# Altium schematic sheet Top.DMX8x8A-v1.SchDoc
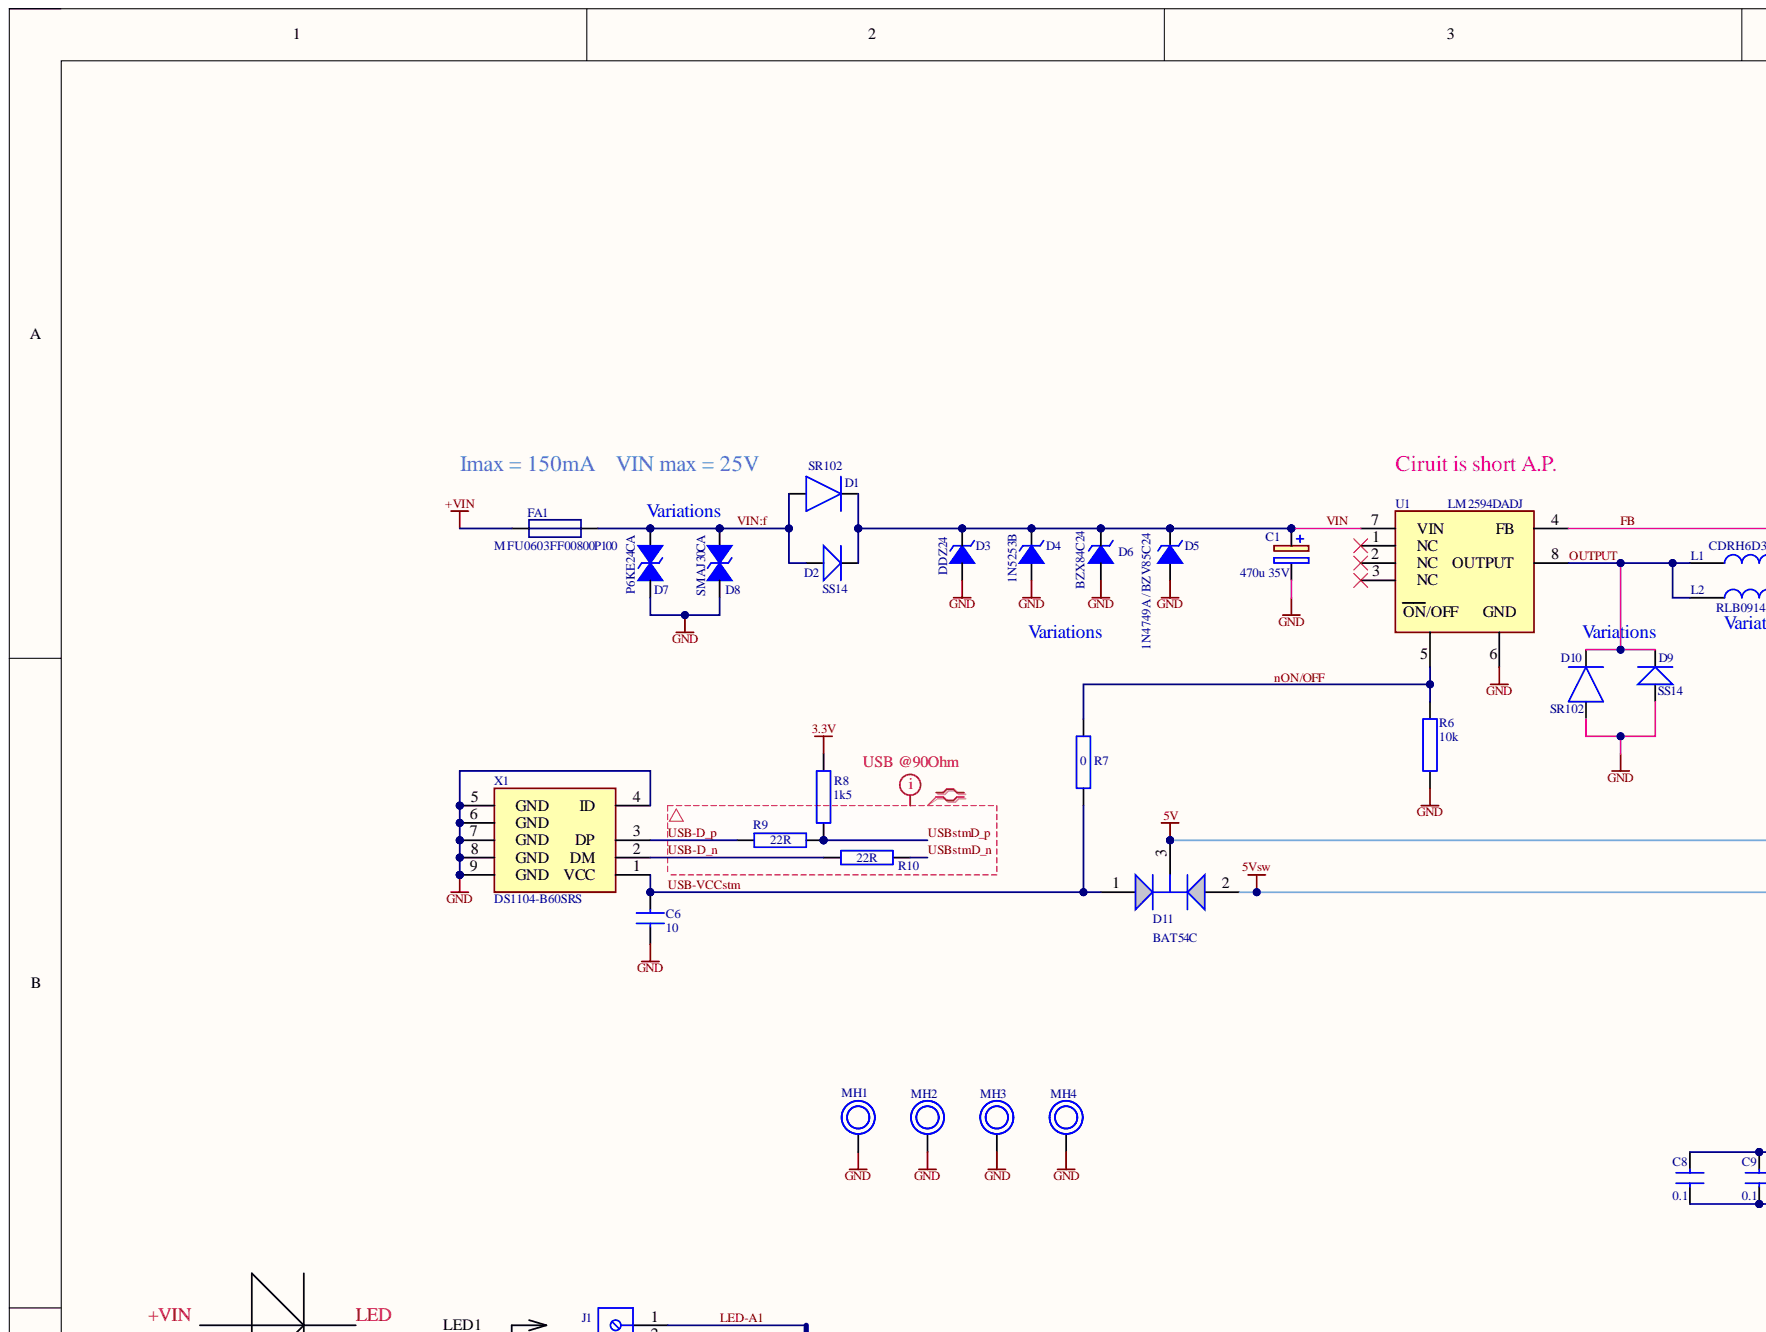
[diagram p0: Top.DMX8x8A-v1.SchDoc, top left]
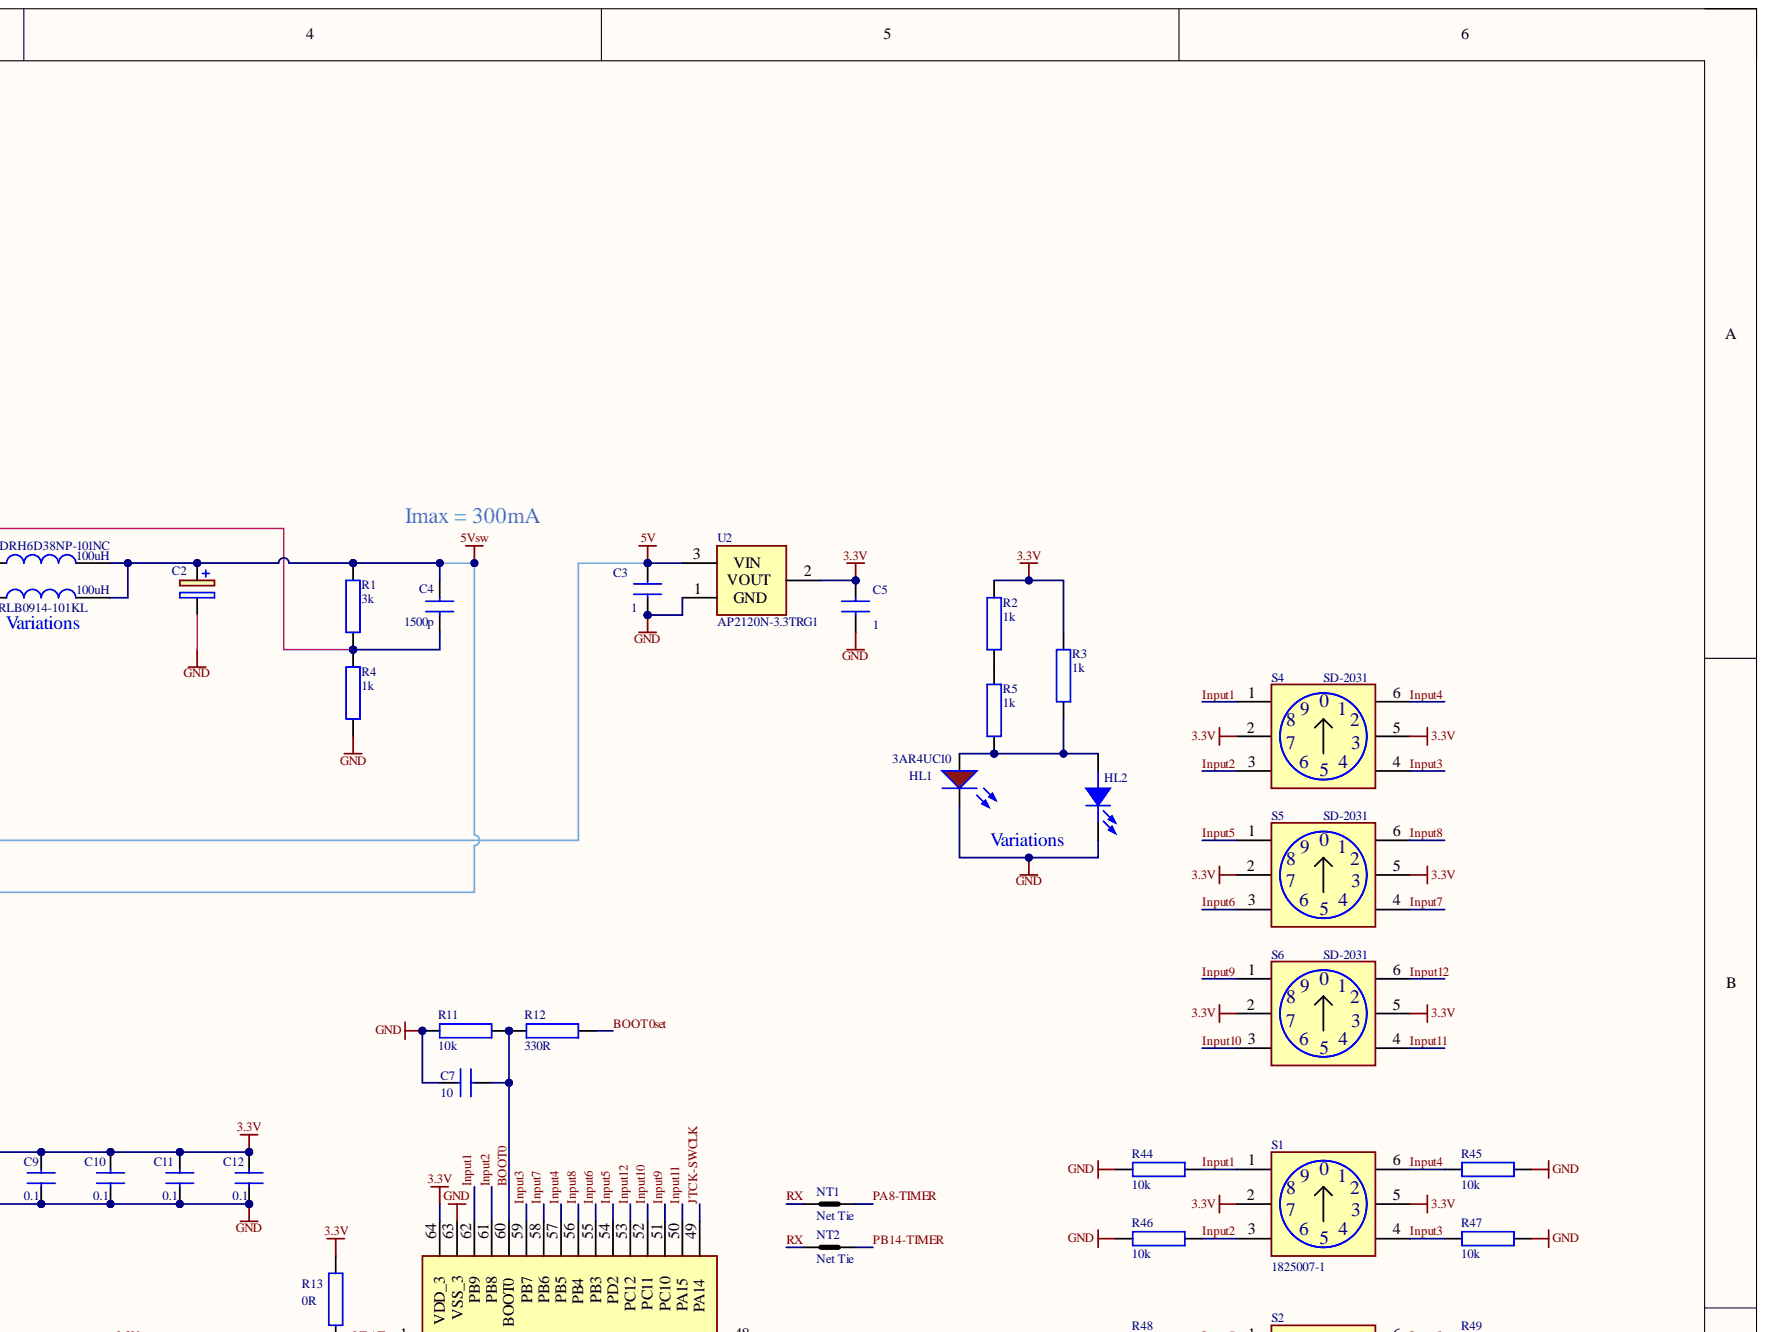
[diagram p1: Top.DMX8x8A-v1.SchDoc, top right]
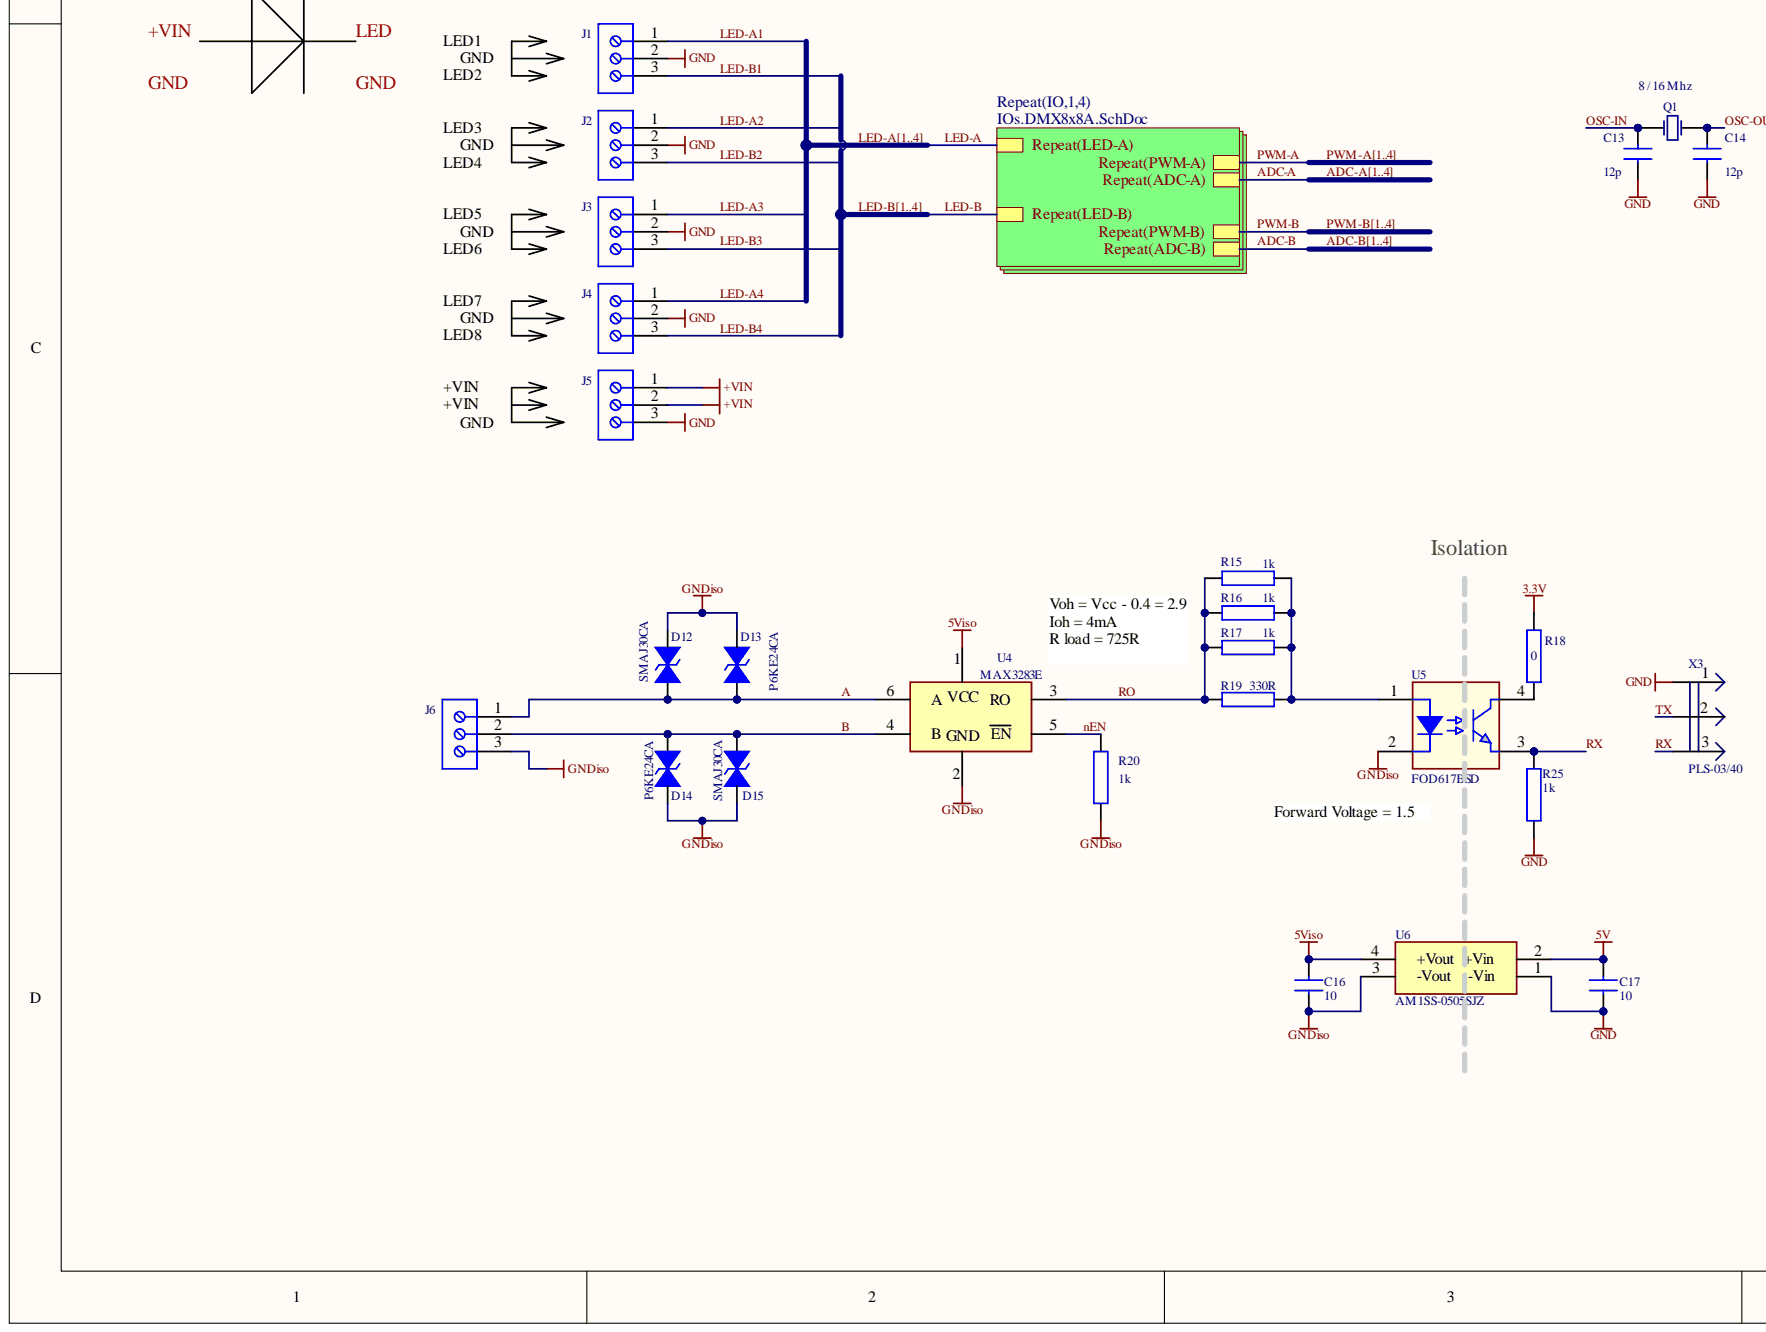
[diagram p2: Top.DMX8x8A-v1.SchDoc, bottom left]
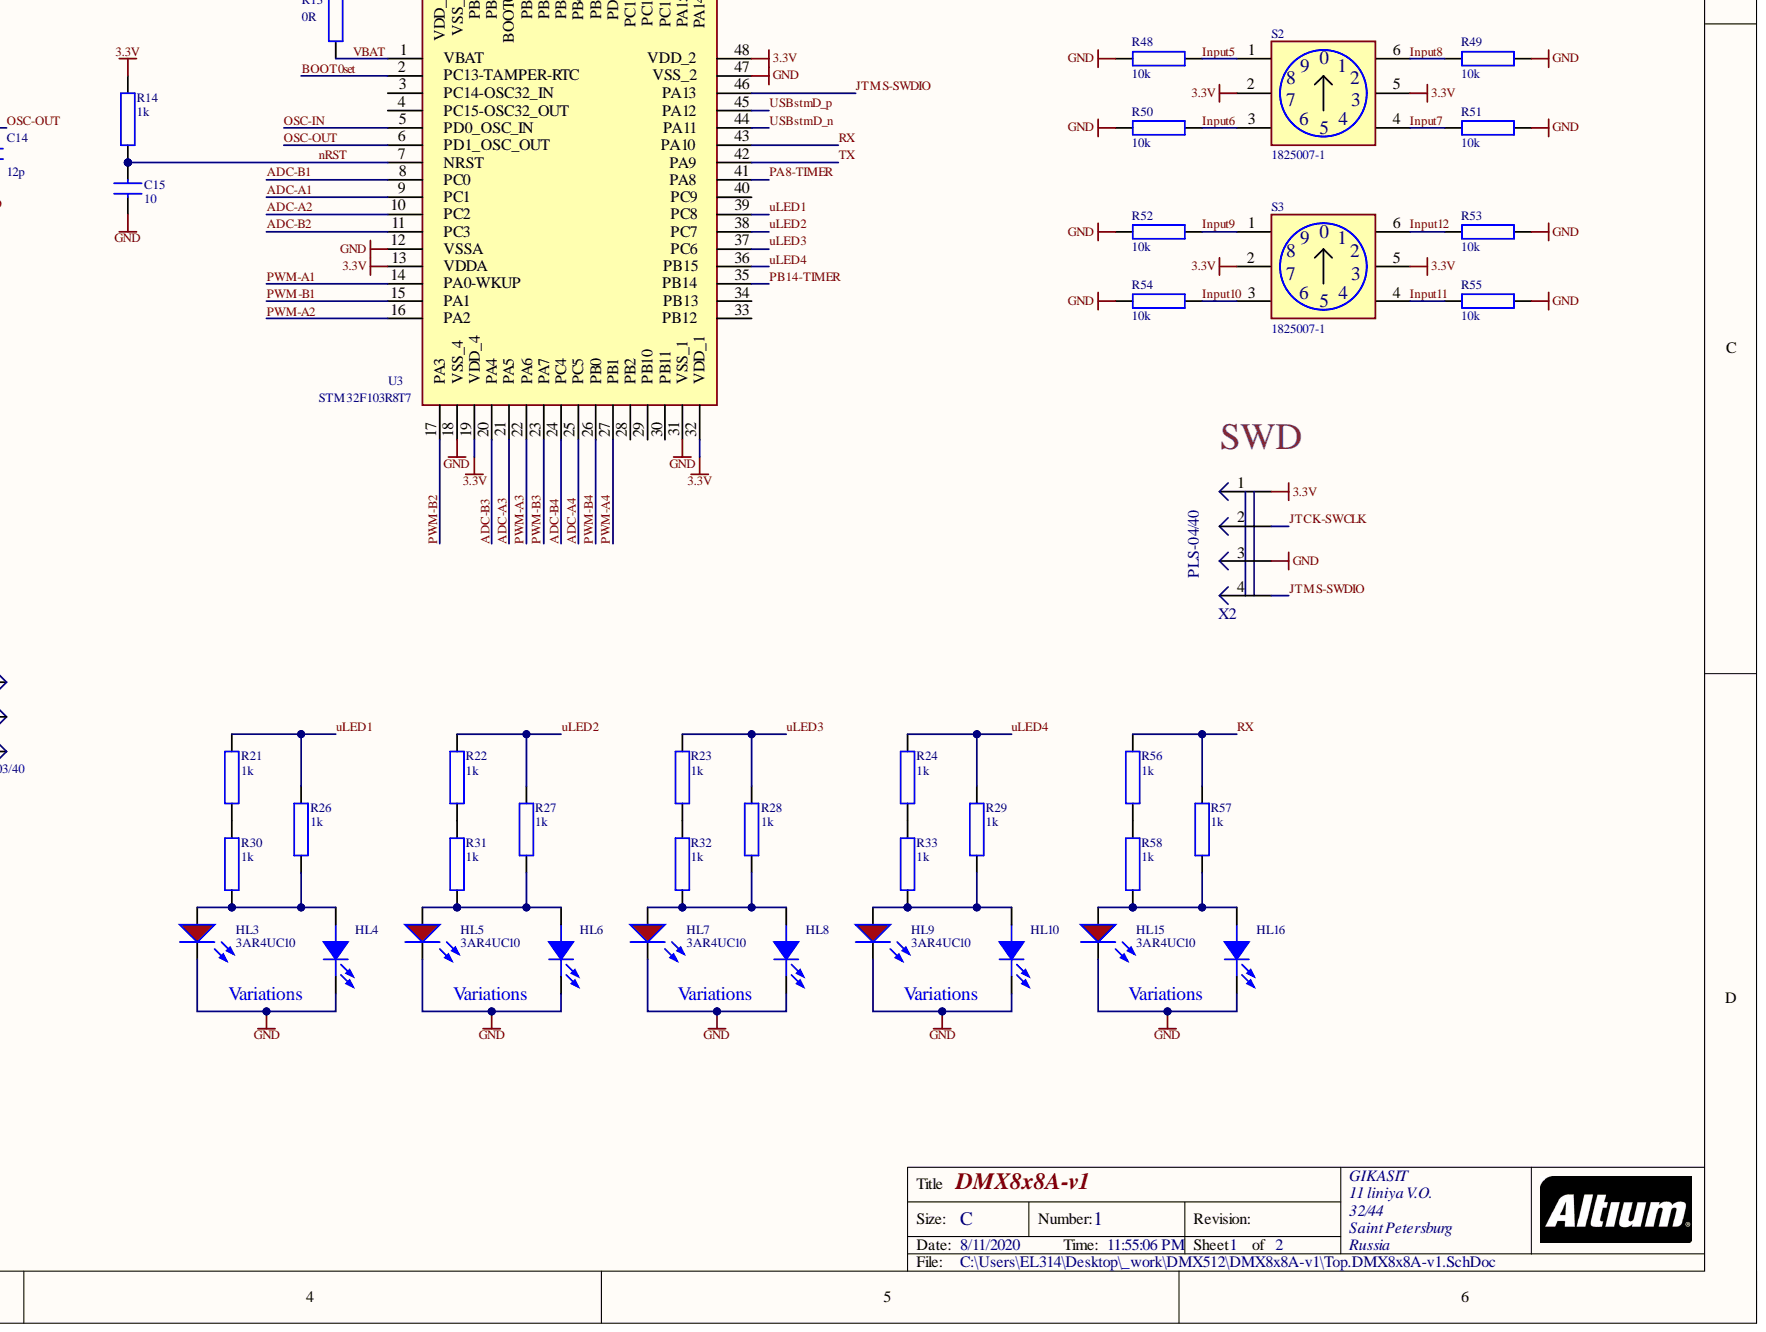
[diagram p3: Top.DMX8x8A-v1.SchDoc, bottom right]

## Parts
C1  value 470u 35V  [CAPPRD500W60D1025H1750]  (hidden comment: 35ZL470MEFC10X20)
C2  35ZL470MEFC10X20  [CAPPRD500W60D1025H1750]  (value not drawn)
C3  value 1  [=copy(currentfootprint,2,4)]  (hidden comment: 1 50V 5%)
C4  value 1500p  [=copy(currentfootprint,2,4)]  (hidden comment: 1500p 25V 20%)
C5  value 1  [=copy(currentfootprint,2,4)]  (hidden comment: 1 50V 5%)
C6  value 10  [=copy(currentfootprint,2,4)]  (hidden comment: 10 50V 5%)
C7  value 10  [=copy(currentfootprint,2,4)]  (hidden comment: 10 50V 5%)
C8  value 0.1  [=copy(currentfootprint,2,4)]  (hidden comment: 0.1 25V 20%)
C9  value 0.1  [=copy(currentfootprint,2,4)]  (hidden comment: 0.1 25V 20%)
C10  value 0.1  [=copy(currentfootprint,2,4)]  (hidden comment: 0.1 25V 20%)
C11  value 0.1  [=copy(currentfootprint,2,4)]  (hidden comment: 0.1 25V 20%)
C12  value 0.1  [=copy(currentfootprint,2,4)]  (hidden comment: 0.1 25V 20%)
C13  value 12p  [=copy(currentfootprint,2,4)]  (hidden comment: 12p 25V 20%)
C14  value 12p  [=copy(currentfootprint,2,4)]  (hidden comment: 12p 25V 20%)
C15  value 10  [=copy(currentfootprint,2,4)]  (hidden comment: 10 50V 5%)
C16  value 10  [=copy(currentfootprint,2,4)]  (hidden comment: 10 50V 5%)
C17  value 10  [=copy(currentfootprint,2,4)]  (hidden comment: 10 50V 5%)
D1  SR102  [DO-204AL (DO-41)]
D2  SS14  [DO-214AC (SMA)]
D3  DDZ24  [SOD323F]
D4  1N5253B  [DO-204AH (DO-35).SLDPRT]
D5  1N4749A / BZV85C24  [DO-204AL (DO-41)]
D6  BZX84C24  [SOT-23-3 (3)]
D7  P6KE24CA  [DIOAD1910W90L670D310 (DO-15)]
D8  SMAJ30CA  [DO-214AC (SMA) biplar]
D9  SS14  [DO-214AC (SMA)]
D10  SR102  [DO-204AL (DO-41)]
D11  BAT54C  [SOT-23-3 (3)]
D12  SMAJ30CA  [DO-214AC (SMA) biplar]
D13  P6KE24CA  [DIOAD1910W90L670D310 (DO-15)]
D14  P6KE24CA  [DIOAD1910W90L670D310 (DO-15)]
D15  SMAJ30CA  [DO-214AC (SMA) biplar]
FA1  MFU0603FF00800P100  [FUSC1608X55N]
HL1  3AR4UC10  [LED-2pin 2.54mm]
HL2  0805 SMD LED  [LED SMD 0805]  (value not drawn)
HL3  3AR4UC10  [LED-2pin 2.54mm]
HL4  ? ?  [LED SMD 0805]  (value not drawn)
HL5  3AR4UC10  [LED-2pin 2.54mm]
HL6  ? ?  [LED SMD 0805]  (value not drawn)
HL7  3AR4UC10  [LED-2pin 2.54mm]
HL8  ? ?  [LED SMD 0805]  (value not drawn)
HL9  3AR4UC10  [LED-2pin 2.54mm]
HL10  ? ?  [LED SMD 0805]  (value not drawn)
HL15  3AR4UC10  [LED-2pin 2.54mm]
HL16  ? ?  [LED SMD 0805]  (value not drawn)
J1  691134710003  [691134710003]  (value not drawn)
J2  691134710003  [691134710003]  (value not drawn)
J3  691134710003  [691134710003]  (value not drawn)
J4  691134710003  [691134710003]  (value not drawn)
J5  691134710003  [691134710003]  (value not drawn)
J6  691134710003  [691134710003]  (value not drawn)
L1  CDRH6D38NP-101NC  value 100uH  [INDPM6767X400N]
L2  RLB0914-101KL  value 100uH  [RLB0914]
MH1  PMH  [Hole Pad 3.2x4mm]  (value not drawn)  (no BOM)
MH2  PMH  [Hole Pad 3.2x4mm]  (value not drawn)  (no BOM)
MH3  PMH  [Hole Pad 3.2x4mm]  (value not drawn)  (no BOM)
MH4  PMH  [Hole Pad 3.2x4mm]  (value not drawn)  (no BOM)
NT1  Net Tie  [Net Tie 0.3]
NT2  Net Tie  [Net Tie 0.3]
Q1  value 8 / 16 Mhz  [HC49SMD]  (hidden comment: Crystal HC/49US SMD)
R1  value 3k  [=copy(currentfootprint,2,4)]  (hidden comment: 3k 5%)
R2  value 1k  [=copy(currentfootprint,2,4)]  (hidden comment: 1k 5%)
R3  value 1k  [=copy(currentfootprint,2,4)]  (hidden comment: 1k 5%)
R4  value 1k  [=copy(currentfootprint,2,4)]  (hidden comment: 1k 5%)
R5  value 1k  [=copy(currentfootprint,2,4)]  (hidden comment: 1k 5%)
R6  value 10k  [=copy(currentfootprint,2,4)]  (hidden comment: 10k 5%)
R7  value 0  [=copy(currentfootprint,2,4)]  (hidden comment: 0 5%)
R8  value 1k5  [=copy(currentfootprint,2,4)]  (hidden comment: 1k5 5%)
R9  value 22R  [=copy(currentfootprint,2,4)]  (hidden comment: 22R 5%)
R10  value 22R  [=copy(currentfootprint,2,4)]  (hidden comment: 22R 5%)
R11  value 10k  [=copy(currentfootprint,2,4)]  (hidden comment: 10k 5%)
R12  value 330R  [=copy(currentfootprint,2,4)]  (hidden comment: 330R 5%)
R13  value 0R  [=copy(currentfootprint,2,4)]  (hidden comment: 0R 1%)
R14  value 1k  [=copy(currentfootprint,2,4)]  (hidden comment: 1k 5%)
R15  value 1k  [=copy(currentfootprint,2,4)]  (hidden comment: 1k 5%)
R16  value 1k  [=copy(currentfootprint,2,4)]  (hidden comment: 1k 5%)
R17  value 1k  [=copy(currentfootprint,2,4)]  (hidden comment: 1k 5%)
R18  value 0  [=copy(currentfootprint,2,4)]  (hidden comment: 0 5%)
R19  value 330R  [=copy(currentfootprint,2,4)]  (hidden comment: 330R 5%)
R20  value 1k  [=copy(currentfootprint,2,4)]  (hidden comment: 1k 5%)
R21  value 1k  [=copy(currentfootprint,2,4)]  (hidden comment: 1k 5%)
R22  value 1k  [=copy(currentfootprint,2,4)]  (hidden comment: 1k 5%)
R23  value 1k  [=copy(currentfootprint,2,4)]  (hidden comment: 1k 5%)
R24  value 1k  [=copy(currentfootprint,2,4)]  (hidden comment: 1k 5%)
R25  value 1k  [=copy(currentfootprint,2,4)]  (hidden comment: 1k 5%)
R26  value 1k  [=copy(currentfootprint,2,4)]  (hidden comment: 1k 5%)
R27  value 1k  [=copy(currentfootprint,2,4)]  (hidden comment: 1k 5%)
R28  value 1k  [=copy(currentfootprint,2,4)]  (hidden comment: 1k 5%)
R29  value 1k  [=copy(currentfootprint,2,4)]  (hidden comment: 1k 5%)
R30  value 1k  [=copy(currentfootprint,2,4)]  (hidden comment: 1k 5%)
R31  value 1k  [=copy(currentfootprint,2,4)]  (hidden comment: 1k 5%)
R32  value 1k  [=copy(currentfootprint,2,4)]  (hidden comment: 1k 5%)
R33  value 1k  [=copy(currentfootprint,2,4)]  (hidden comment: 1k 5%)
R44  value 10k  [=copy(currentfootprint,2,4)]  (hidden comment: 10k 5%)
R45  value 10k  [=copy(currentfootprint,2,4)]  (hidden comment: 10k 5%)
R46  value 10k  [=copy(currentfootprint,2,4)]  (hidden comment: 10k 5%)
R47  value 10k  [=copy(currentfootprint,2,4)]  (hidden comment: 10k 5%)
R48  value 10k  [=copy(currentfootprint,2,4)]  (hidden comment: 10k 5%)
R49  value 10k  [=copy(currentfootprint,2,4)]  (hidden comment: 10k 5%)
R50  value 10k  [=copy(currentfootprint,2,4)]  (hidden comment: 10k 5%)
R51  value 10k  [=copy(currentfootprint,2,4)]  (hidden comment: 10k 5%)
R52  value 10k  [=copy(currentfootprint,2,4)]  (hidden comment: 10k 5%)
R53  value 10k  [=copy(currentfootprint,2,4)]  (hidden comment: 10k 5%)
R54  value 10k  [=copy(currentfootprint,2,4)]  (hidden comment: 10k 5%)
R55  value 10k  [=copy(currentfootprint,2,4)]  (hidden comment: 10k 5%)
R56  value 1k  [=copy(currentfootprint,2,4)]  (hidden comment: 1k 5%)
R57  value 1k  [=copy(currentfootprint,2,4)]  (hidden comment: 1k 5%)
R58  value 1k  [=copy(currentfootprint,2,4)]  (hidden comment: 1k 5%)
S1  1825007-1  [1825007-1]
S2  1825007-1  [1825007-1]
S3  1825007-1  [1825007-1]
S4  SD-2031  [SD-2031]
S5  SD-2031  [SD-2031]
S6  SD-2031  [SD-2031]
U1  LM2594DADJ  [SOIC-8]
U2  AP2120N-3.3TRG1  [SOT-23-3 (3)]
U3  STM32F103R8T7  [LQFP64 (QFP50P1200X1200X160-64N)]
U4  MAX3283E  [SOT23-6 (SOT95P280X145-6N)]
U5  FOD617BSD  [FOD617BSD]
U6  AM1SS-0505SJZ  [AM1S]
X1  DS1104-B60SRS  [DS1104-B60SRS]
X2  PLS-04/40  [PLS-04]
X3  PLS-03/40  [PLS-03]

## Nets
3.3V: C5.2 C8.2 C9.2 C10.2 C11.2 C12.2 R2.2 R3.2 R8.2 R13.2 R14.2 R18.2 S1.2 S1.5 S2.2 S2.5 S3.2 S3.5 S4.2 S4.5 S5.2 S5.5 S6.2 S6.5 U2.2 U3.13(VDDA) U3.19(VDD_4) U3.32(VDD_1) U3.48(VDD_2) U3.64(VDD_3) X2.1
5V: C3.2 C17.2 D11.3 U2.3 U6.2(+Vin)
5Viso: C16.2 U4.1 U6.4(+Vout)
5Vsw: C2.1 C4.2 D11.2 L1.2 L2.2 R1.2
+VIN: FA1.1 J5.1 J5.2
GND: C1.2 C2.2 C3.1 C5.1 C6.1 C7.2 C8.1 C9.1 C10.1 C11.1 C12.1 C13.1 C14.1 C15.1 C17.1 D3.2 D4.2 D5.2 D6.1 D7.1 D8.1 D9.1 D10.1 HL1.2 HL2.2 HL3.2 HL4.2 HL5.2 HL6.2 HL7.2 HL8.2 HL9.2 HL10.2 HL15.2 HL16.2 J1.2 J2.2 J3.2 J4.2 J5.3 MH1.1 MH2.1 MH3.1 MH4.1 R4.1 R6.1 R11.1 R25.1 R44.1 R45.1 R46.1 R47.1 R48.1 R49.1 R50.1 R51.1 R52.1 R53.1 R54.1 R55.1 U1.6 U2.1 U3.12(VSSA) U3.18(VSS_4) U3.31(VSS_1) U3.47(VSS_2) U3.63(VSS_3) U6.1(-Vin) X1.4(ID) X1.5(GND) X1.6(GND) X1.7(GND) X1.8(GND) X1.9(GND) X2.3 X3.1
GNDiso: C16.1 D12.1 D13.1 D14.1 D15.1 J6.3 R20.1 U4.2 U5.2 U6.3(-Vout)
A: D12.2 D13.2 J6.1 U4.6(A_VCC)
ADC-A1: U3.9(PC1)  [also labelled ADC-A]
ADC-A2: U3.10(PC2)  [also labelled ADC-A]
ADC-A3: U3.21(PA5)  [also labelled ADC-A]
ADC-A4: U3.25(PC5)  [also labelled ADC-A]
ADC-B1: U3.8(PC0)  [also labelled ADC-B]
ADC-B2: U3.11(PC3)  [also labelled ADC-B]
ADC-B3: U3.20(PA4)  [also labelled ADC-B]
ADC-B4: U3.24(PC4)  [also labelled ADC-B]
B: D14.2 D15.2 J6.2 U4.4(B_GND)
BOOT0: C7.1 R11.2 R12.1 U3.60(BOOT0)
BOOT0set: R12.2 U3.2(PC13-TAMPER-RTC)
FB: C4.1 R1.1 R4.2 U1.4(FB)
Input1: R44.2 S1.1 S4.1 U3.62(PB9)
Input2: R46.2 S1.3 S4.3 U3.61(PB8)
Input3: R47.2 S1.4 S4.4 U3.59(PB7)
Input4: R45.2 S1.6 S4.6 U3.57(PB5)
Input5: R48.2 S2.1 S5.1 U3.54(PD2)
Input6: R50.2 S2.3 S5.3 U3.55(PB3)
Input7: R51.2 S2.4 S5.4 U3.58(PB6)
Input8: R49.2 S2.6 S5.6 U3.56(PB4)
Input9: R52.2 S3.1 S6.1 U3.51(PC10)
Input10: R54.2 S3.3 S6.3 U3.52(PC11)
Input11: R55.2 S3.4 S6.4 U3.50(PA15)
Input12: R53.2 S3.6 S6.6 U3.53(PC12)
JTCK-SWCLK: U3.49(PA14) X2.2
JTMS-SWDIO: U3.46(PA13) X2.4
LED-A1: J1.1  [also labelled LED-A]
LED-A2: J2.1  [also labelled LED-A]
LED-A3: J3.1  [also labelled LED-A]
LED-A4: J4.1  [also labelled LED-A]
LED-B1: J1.3  [also labelled LED-B]
LED-B2: J2.3  [also labelled LED-B]
LED-B3: J3.3  [also labelled LED-B]
LED-B4: J4.3  [also labelled LED-B]
nEN: R20.2 U4.5(EN)
nON/OFF: R6.2 R7.2 U1.5
nRST: C15.2 R14.1 U3.7(NRST)
OSC-IN: C13.2 Q1.1 U3.5(PD0_OSC_IN)
OSC-OUT: C14.2 Q1.2 U3.6(PD1_OSC_OUT)
OUTPUT: D9.2 D10.2 L1.1 L2.1 U1.8(OUTPUT)
PA8-TIMER: NT1.2 U3.41(PA8)
PB14-TIMER: NT2.2 U3.35(PB14)
PWM-A1: U3.14(PA0-WKUP)  [also labelled PWM-A]
PWM-A2: U3.16(PA2)  [also labelled PWM-A]
PWM-A3: U3.22(PA6)  [also labelled PWM-A]
PWM-A4: U3.27(PB1)  [also labelled PWM-A]
PWM-B1: U3.15(PA1)  [also labelled PWM-B]
PWM-B2: U3.17(PA3)  [also labelled PWM-B]
PWM-B3: U3.23(PA7)  [also labelled PWM-B]
PWM-B4: U3.26(PB0)  [also labelled PWM-B]
RO: R15.1 R16.1 R17.1 R19.1 U4.3(RO)
RX: NT1.1 NT2.1 R25.2 R56.2 R57.2 U3.43(PA10) U5.3 X3.3
TX: U3.42(PA9) X3.2
uLED1: R21.2 R26.2 U3.39(PC8)
uLED2: R22.2 R27.2 U3.38(PC7)
uLED3: R23.2 R28.2 U3.37(PC6)
uLED4: R24.2 R29.2 U3.36(PB15)
USB-D_n: R10.1 X1.2(DM)
USB-D_p: R9.1 X1.3(DP)
USB-VCCstm: C6.2 D11.1 R7.1 X1.1(VCC)
USBstmD_n: R10.2 U3.44(PA11)
USBstmD_p: R8.1 R9.2 U3.45(PA12)
VBAT: R13.1 U3.1(VBAT)
VIN: C1.1 D1.2 D2.2 D3.1 D4.1 D5.1 D6.3 U1.7(VIN)
VIN:f: D1.1 D2.1 D7.2 D8.2 FA1.2
NetHL1_1: HL1.1 HL2.1 R3.1 R5.1
NetHL3_1: HL3.1 HL4.1 R26.1 R30.1
NetHL5_1: HL5.1 HL6.1 R27.1 R31.1
NetHL7_1: HL7.1 HL8.1 R28.1 R32.1
NetHL9_1: HL9.1 HL10.1 R29.1 R33.1
NetHL15_1: HL15.1 HL16.1 R57.1 R58.1
NetR2_1: R2.1 R5.2
NetR15_2: R15.2 R16.2 R17.2 R19.2 U5.1
NetR18_1: R18.1 U5.4
NetR21_1: R21.1 R30.2
NetR22_1: R22.1 R31.2
NetR23_1: R23.1 R32.2
NetR24_1: R24.1 R33.2
NetR56_1: R56.1 R58.2
NetU1_1: U1.1(NC)
NetU1_2: U1.2(NC)
NetU1_3: U1.3(NC)
NetU3_3: U3.3(PC14-OSC32_IN)
NetU3_4: U3.4(PC15-OSC32_OUT)
NetU3_28: U3.28(PB2)
NetU3_29: U3.29(PB10)
NetU3_30: U3.30(PB11)
NetU3_33: U3.33(PB12)
NetU3_34: U3.34(PB13)
NetU3_40: U3.40(PC9)

## Library data of the parts
C1 C2 = 35ZL470MEFC10X20: Radial Lead Aluminum Electrolytic Capacitors; SMD/TH: TH; Component Kind: Standard; HelpURL: http://www.rubycon.co.jp/en/catalog/e_pdfs/aluminum/e_zl.pdf; Library Name: DMX512.SchLib
C3 C5-C7 C15-C17 = Ceramic SMD Cap_R: Ceramic Chip Capacitor; SMD/TH: SMD; Value2: 50V 5%; Component Kind: Standard; ComponentLink1Description: Local Datasheet; HelpURL: https://www.mouser.com/catalog/supplier/library/AVXShortForm.pdf; Library Name: Capacitors.IntLib; ComponentLink1URL: V:\Libraries\Passives\RLC\Capacitors\AVXShortForm.pdf
C4 C8-C14 = Ceramic SMD Cap_R: Ceramic Chip Capacitor; Value2: 25V 20%; Component Kind: Standard; ComponentLink1Description: Local Datasheet; HelpURL: https://www.mouser.com/catalog/supplier/library/AVXShortForm.pdf; Library Name: Capacitors.IntLib; ComponentLink1URL: V:\Libraries\Passives\RLC\Capacitors\AVXShortForm.pdf
D1 D10 = SR102: Schottky Barrier Rectifier; SMD/TH: TH; Component Kind: Standard; HelpURL: https://ru.mouser.com/Semiconductors/Discrete-Semiconductors/Diodes-Rectifiers/Schottky-Diodes-Rectifiers/Datasheets/_/N-awhng?keyword=SR103; Library Name: DMX512.SchLib
D2 = SS14: Surface Mount Schottky Barrier Rectifier 1A; SMD/TH: SMD; Component Kind: Standard; HelpURL: http://www.vishay.com/docs/88746/ss12.pdf; Library Name: DMX512.SchLib
D3 = DDZ: Zener 0.5w SOD323F; Mfr: Diodes Incorporated; Name1: 24; SMD/TH: SMD; Component Kind: Standard; HelpURL: https://www.diodes.com/assets/Datasheets/ds31987.pdf; Library Name: Diodes.IntLib
D4 = 1N5253B: Zener DO-35; Mfr: ON Semi; SMD/TH: SMD; Component Kind: Standard; HelpURL: https://www.onsemi.com/pub/Collateral/1N5221B-D.PDF; Library Name: DMX512.SchLib
D5 = Zener DO-41: SMD/TH: TH; Component Kind: Standard; Description: Zener DO-41; HelpURL: https://assets.nexperia.com/documents/data-sheet/1N4728A_SER.pdf; Library Name: DMX512.SchLib
D6 = BZX84C13LT1G: Zener regulator, 27V; Mfr: ON Semi; Component Kind: Standard; ComponentLink1Description: Local Datasheet; HelpURL: https://www.onsemi.com/pub/Collateral/BZX84C2V4LT1-D.PDF; Library Name: Diodes.IntLib; ComponentLink1URL: V:\Libraries\Discrete\Diodes\Doc\BZX84C2V4LT1-D.PDF
D7 D13 D14 = P6KE: TVS Axial 600W Unipolar 24V; SMD/TH: TH; Component Kind: Standard; HelpURL: https://m.littelfuse.com/~/media/electronics/datasheets/tvs_diodes/littelfuse_tvs_diode_p6ke_datasheet.pdf.pdf; Library Name: DMX512.SchLib
D8 D12 D15 = SMAJ30CA: TVS Uni 30V; Mfr: ST; SMD/TH: SMD; Component Kind: Standard; ComponentLink1Description: Local Datasheet; HelpURL: https://www.st.com/resource/en/datasheet/smaj.pdf; Library Name: TVS.IntLib; ComponentLink1URL: V:\Libraries\Discrete\TVS\1724007.pdf
D9 = SS12: Surface Mount Schottky Barrier Rectifier; SMD/TH: SMD; Component Kind: Standard; HelpURL: http://www.vishay.com/docs/88746/ss12.pdf; Library Name: DMX512.SchLib
D11 = BAT54C: SURFACE MOUNT SCHOTTKY BARRIER DIODE; Component Kind: Standard; ComponentLink1Description: Local Datasheet; HelpURL: https://www.diodes.com/assets/Datasheets/ds11005.pdf; Library Name: Diodes.IntLib; ComponentLink1URL: V:\Libraries\Discrete\Diodes\ds11005.pdf
FA1 = Fuse MFU: Thin Film Chip Fuse; SMD/TH: SMD; Component Kind: Standard; HelpURL: http://www.vishay.com/docs/28747/mfuserie.pdf; Library Name: DMX512.SchLib
HL1 HL3 HL5 HL7 HL9 HL15 = 3AR4UC10: LED 3mm Red; SMD/TH: TH; Component Kind: Standard; HelpURL: http://www.triatron.ru/upload/catalog_photo/elements/pdf/006531.pdf; Library Name: DMX512.SchLib
HL2 = LED 0805: Color: ?; Component Kind: Standard; Description: LED 0805; Library Name: DMX512.SchLib; PN: ?; SMD/TH: SMD
HL4 HL6 HL8 HL10 HL16 = LED 0805: SMD/TH: SMD; Color: ?; Component Kind: Standard; Description: LED 0805; Library Name: DMX512.SchLib; PN: ?
J1-J6 = 691134710003: WR-TBL Serie 1347 Horizontal Cable Entry, Pressure Clamp, pitch 5mm, 3p; Compliance: Lead free / RoHS; Modularity: Yes; PCB/Cable/Panel: PCB; Pin Number: 3; Rated Current [UL]: 16A; SMD/TH: TH; Working Voltage [UL]: 300VAC; Application: Pressure Clamp; Component Kind: Standard; ComponentLink1Description: Manufacturer; ComponentLink1URL: http://www.we-online.de; ComponentLink2Description: Datasheet; ComponentLink2URL: https://katalog.we-online.de/em/datasheet/691134710003.pdf; HelpURL: https://www.we-online.de/katalog/datasheet/691134710003.pdf; Library Name: DMX512.SchLib; Operating Temperature: -30 to +120degC; Packaging: Box; Part Number: 691134710003; Pitch: 5mm; Published Date: October 2019; Type: Horizontal; Publisher: Wurth Elektronik
L1 = CDRH6D38NP: SMD Power Inductor 100uH 0.65A 265mOhms; Mfr: Sumida; Component Kind: Standard; HelpURL: http://products.sumida.com/products/pdf/CDRH6D38.pdf; Library Name: DMX512.SchLib; SMD/TH: SMD
L2 = RLB0914-101KL: Radial Fixed Through Hole Inductor 100uH Bourns 100 uH +/-10%; SMD/TH: TH; Component Kind: Standard; HelpURL: https://www.bourns.com/pdfs/rlb.pdf; Library Name: DMX512.SchLib
MH1-MH4 = PMH: Plated Mounting Hole; Library Name: Misc.IntLib
Q1 = Crystal HC49SMD: HC/49US (AT49) LOW PROFILE SURFACE  MOUNT MICROPROCESSOR CRYSTAL; Value: 8 / 16 Mhz; Component Kind: Standard; HelpURL: https://abracon.com/Resonators/ABLS2.pdf; Library Name: DMX512.SchLib
R1-R33 R44-R58 = SMD Res_R: Chip Resistor; Component Kind: Standard; ComponentLink1Description: Local Datasheet; HelpURL: http://www.vishay.com/docs/49252/_dbr_smd_resistor_product_overview_vmn_sg2189_1705.pdf; ComponentLink1URL: V:\Libraries\Passives\Resistors\_dbr_smd_resistor_product_overview_vmn_sg2189_1705.pdf
  R1-R12 R14-R33 R44-R58: Library Name: Resistors.IntLib; Value2: 5%
  R13: Library Name: DMX512.SchLib; Value2: 1%
S1-S3 = 1825007-1: Alcoswitch DIP, Rotary Switches, 10 Positions, Flush Actuator Style, Rotary Switch Style, Rotary DIP Switch Type, 10 Switches, Thermoplastic, Sealed; SMD/TH: TH; Component Kind: Standard; HelpURL: https://www.te.com/commerce/DocumentDelivery/DDEController?Action=showdoc&DocId=Customer+Drawing%7F1825007%7FA5%7Fpdf%7FEnglish%7FENG_CD_1825007_A5.pdf%7F1825007-1; Library Name: DMX512.SchLib
S4-S6 = SD-2031: ROTARY CODED SWITCHES; SMD/TH: TH; Component Kind: Standard; HelpURL: https://www.nidec-copal-electronics.com/e/catalog/switch/sd-1000&sd-2000.pdf; Library Name: DMX512.SchLib
U1 = LM2594DADJ: 0.5 A, Step-Down Switching Regulator; Mfr: ON Semiconductor; SMD/TH: SMD; Component Kind: Standard; HelpURL: http://www.onsemi.com/pub/Collateral/LM2594-D.PDF; Library Name: DMX512.SchLib
U2 = AP2120N-3.3TRG1: High Speed, Extremely Low Noise LDO Regulator; Manufacturer_Name: Diodes Inc.; Allied_Number: 70550990; SMD/TH: SMD; Allied Price/Stock: https://www.alliedelec.com/diodesinc-ap2120n-3-3trg1/70550990/; Component Kind: Standard; Datasheet Link: https://componentsearchengine.com/Datasheets/1/AP2120N-3.3TRG1.pdf; Height: 1.2mm; HelpURL: https://www.diodes.com/assets/Datasheets/AP2120.pdf; Library Name: DMX512.SchLib; Manufacturer_Part_Number: AP2120N-3.3TRG1; Mouser Part Number: 621-AP2120N-3.3TRG1; Mouser Price/Stock: https://www.mouser.com/Search/Refine.aspx?Keyword=621-AP2120N-3.3TRG1; RS Part Number: 8287334P; RS Price/Stock: http://uk.rs-online.com/web/p/products/8287334P
U3 = STM32F103R8T7: Medium-density performance line ARM®-based 32-bit MCU with 64 or 128 KB Flash, USB, CAN, 7 timers, 2 ADCs, 9 com. interfaces; Mfr: STMicroelectronics; SMD/TH: SMD; Component Kind: Standard; ComponentLink1Description: Reference Manual; ComponentLink1URL: https://www.st.com/resource/en/reference_manual/cd00171190-stm32f101xx-stm32f102xx-stm32f103xx-stm32f105xx-and-stm32f107xx-advanced-arm-based-32-bit-mcus-stmicroelectronics.pdf; Height: 1.6mm; HelpURL: https://www.st.com/resource/en/datasheet/stm32f103r8.pdf; Library Name: DMX512.SchLib
U4 = MAX3283E: 15kV ESD-Protected 52Mbps, 3V to 5.5V,  SOT23 RS-485/RS-422 True Fail-Safe Receiver; Manufacturer_Name: Maxim Integrated; Manufacturer_Part_Number: MAX3283EAUT+T; SMD/TH: SMD; Component Kind: Standard; Datasheet Link: https://datasheets.maximintegrated.com/en/ds/MAX3280E-MAX3284E.pdf; Height: 1.45mm; HelpURL: https://datasheets.maximintegrated.com/en/ds/MAX3280E-MAX3284E.pdf; Library Name: DMX512.SchLib; Mouser Part Number: 700-MAX3283EAUTT; Mouser Price/Stock: https://www.mouser.com/Search/Refine.aspx?Keyword=700-MAX3283EAUTT; RS Part Number: 9237298; RS Price/Stock: https://uk.rs-online.com/web/p/products/9237298
U5 = FOD617BSD: 4-Pin High Operating Temperature  Phototransistor Optocouplers; Manufacturer_Name: ON Semiconductor; Manufacturer_Part_Number: FOD814; SMD/TH: TH; Component Kind: Standard; Datasheet Link: http://www.onsemi.com/pub/Collateral/FOD814-D.PDF; Height: 4.4mm; HelpURL: https://4donline.ihs.com/images/VipMasterIC/IC/FAIR/FAIRS24026/FAIRS24026-1.pdf?hkey=EC6BD57738AE6E33B588C5F9AD3CEFA7; Library Name: DMX512.SchLib; Mouser Part Number: 512-FOD814; Mouser Price/Stock: https://www.mouser.com/Search/Refine.aspx?Keyword=512-FOD814; RS Part Number: 7599311P; RS Price/Stock: http://uk.rs-online.com/web/p/products/7599311P
U6 = AM1SS-0505SJZ: Isolated DC-DC Converter 1 Watt; Manufacturer_Name: aimtec; Manufacturer_Part_Number: AM1SS-1205S-NZ; SMD/TH: SMD; Component Kind: Standard; Datasheet Link: http://www.aimtec.com/site/Aimtec/files/Datasheet/HighResolution/am1ss-nz.pdf; Height: 10.16mm; HelpURL: http://www.aimtec.com/site/Aimtec/files/Datasheet/HighResolution/am1ss-nz.pdf; Library Name: DMX512.SchLib
X1 = miniUSB: mini USB on PCB; Component Kind: Standard; HelpURL: https://static.chipdip.ru/lib/028/DOC001028880.pdf; Library Name: USB.Schlib
X2 = PLS-04: Pin header, Vertcial, Strips, Male, 2.54mm pitch; Mfr: Бурый медведь; SMD/TH: TH; Component Kind: Standard; PN: PLS-04/40; HelpURL: Y:\LIBRARY\Altium Designer\Datasheets\Connectors\00789-Штыревые разъемы Платан.pdf; Library Name: Conx.IntLib
X3 = PLS-03: Разъем на плату, 2.54 мм шаг; Mfr: Бурый медведь; Component Kind: Standard; Library Name: Connectors.IntLib; PN: PLS-03/40; HelpURL: Y:\LIBRARY\Altium Designer\Datasheets\Connectors\00789-Штыревые разъемы Платан.pdf
NT1 NT2 = Net Tie: Component Kind: Net Tie; Description: Net Tie; Library Name: Misc.IntLib
